# Altium schematic sheet MKRWAN_1300.SchDoc(MKRWAN_1300)
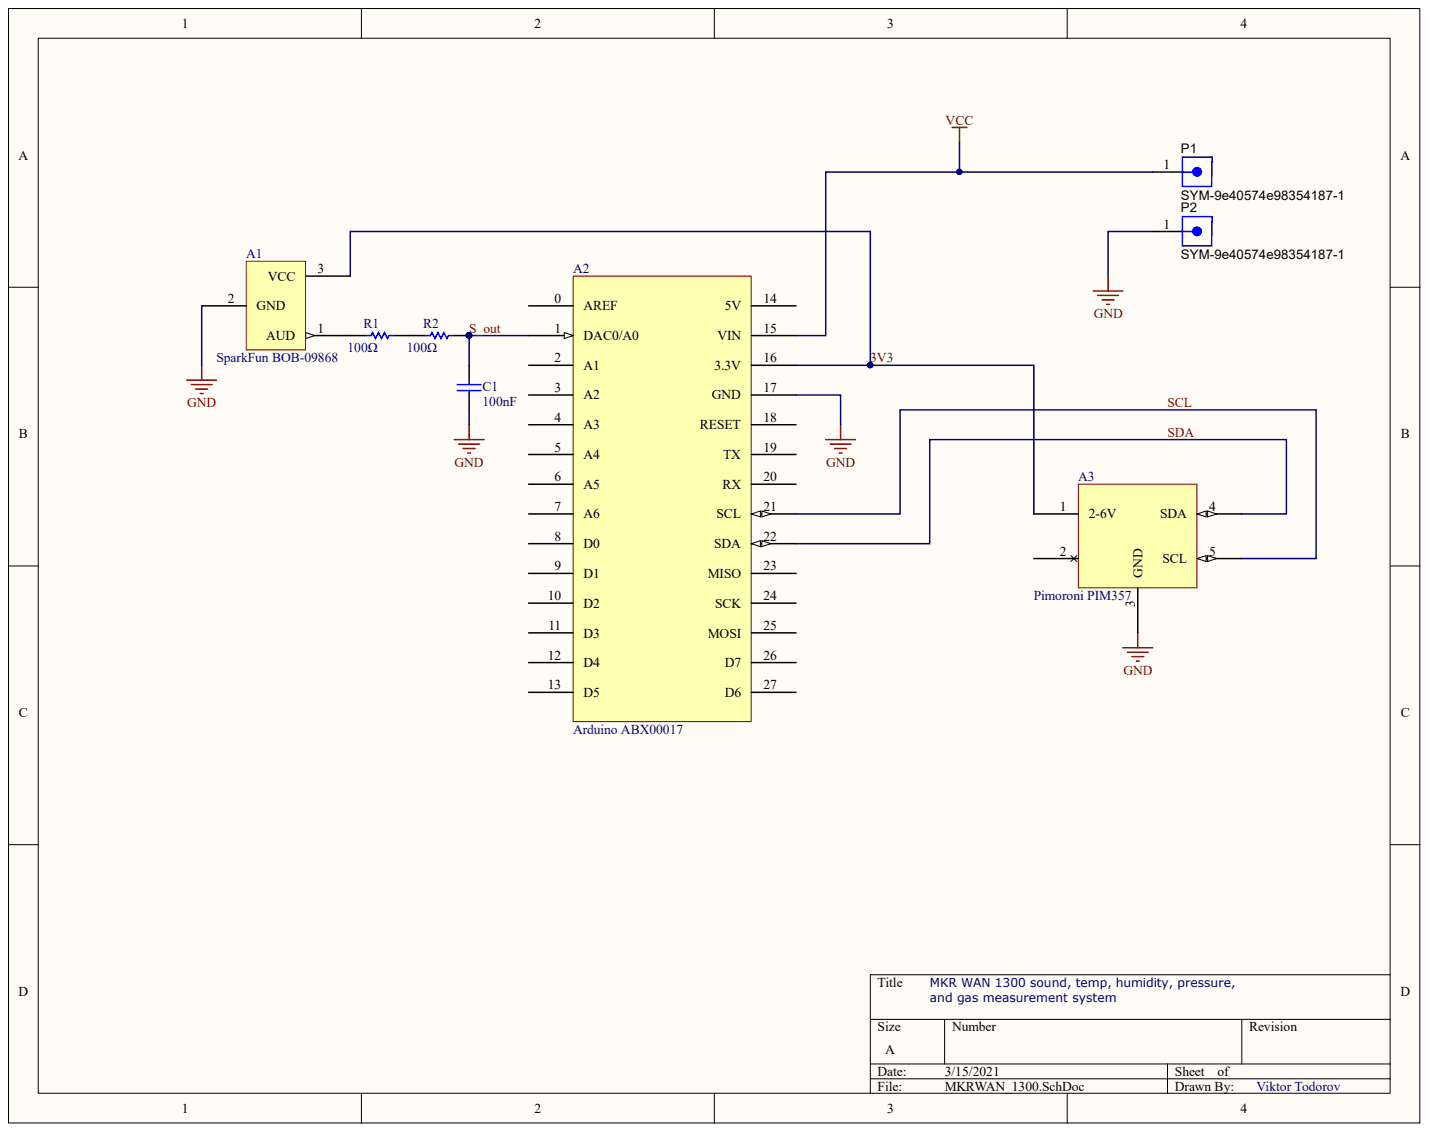
[diagram p0: MKRWAN_1300.SchDoc]

## Parts
A1  SparkFun BOB-09868  Manufacturer Part Number: BOB-09868  [PCB-1potsi048ebqysjrldfj-1]
A2  Arduino ABX00017  Manufacturer Part Number: ABX00017  [PCB-bb69x4j479kxrmlxcux2-1]
A3  Pimoroni PIM357  Manufacturer Part Number: PIM357  [PCB-qvs4aq9kb5po7iowkm1p-1]
C1  100nF  [PCB-sa3w44oy0wih14a9m5c9-1]
P1  SYM-9e40574e98354187-1  [PCB-lm1opiol0yx140ggi4xe-1]
P2  SYM-9e40574e98354187-1  [PCB-lm1opiol0yx140ggi4xe-1]
R1  value 100Ω  [PCB-uegyx4izrxx2o2dg06ir-1]  (hidden comment: TE Connectivity / AMP 1622297-1)
R2  value 100Ω  [PCB-uegyx4izrxx2o2dg06ir-1]  (hidden comment: TE Connectivity / AMP 1622297-1)

## Nets
GND: A1.2 A2.17(GND) A3.3(GND) C1.1 P2.1
VCC: A2.15(VIN) P1.1
3V3: A1.3 A2.16(3.3V) A3.1(2-6V)
S_OUT: A2.1(DAC0/A0) C1.2 R2.2
SCL: A2.21(SCL) A3.5(SCL)
SDA: A2.22(SDA) A3.4(SDA)
NetA1_1: A1.1 R1.1
NetR1_2: R1.2 R2.1

Not connected: A2 0(AREF) 2(A1) 3 4(A3) 5(A4) 6(A5) 7(A6) 8(D0) 9(D1) 10(D2) 11(D3) 12(D4) 13(D5) 14(5V) 18(RESET) 19(TX) 20(RX) 23(MISO) 24(SCK) 25(MOSI) 26(D7) 27(D6); A3 2

## Library data of the parts
A1 = CMP-6b5aa3011b4bb49d-10: ADMP401 Mems Microphone; Component Kind: Standard; Library Name: FavoritesLibrary; OctopartID: 6b5aa3011b4bb49d; Octopart URL: https://octopart.com/bob-09868-sparkfun-22107511; Weight: 0.00 g
A2 = CMP-b04a7c109d4c6f8d-12: SAMD21 Microcontroller Development Board 0.03276MHz/48MHz CPU 32KB RAM 256KB Flash; Core Architecture: ARM; Number of Bits: 32; Component Kind: Standard; Library Name: FavoritesLibrary; OctopartID: b04a7c109d4c6f8d; Octopart URL: https://octopart.com/abx00017-arduino-89131104; RoHS: Compliant
A3 = CMP-dcca10411d86030d-10: Temperature Sensor, Bme680 Breakout Rohs Compliant: Yes; Component Kind: Standard; Library Name: FavoritesLibrary; OctopartID: dcca10411d86030d; Octopart URL: https://octopart.com/pim357-pimoroni-92398634
C1 = CMP-0012c9195eeddfd5-6: Cap Ceramic 0.1uF 50V X7R 10% Radial 5.08mm 125°C Bulk; Lead Length: 7.00 mm; Capacitance: 100 nF; Component Kind: Standard; Library Name: CommunityVault; Mounting Style: Through Hole; Packaging: Bulk; Size-Length: 5.08 mm
P1 P2 = CMP-df4d166140c6008a-2: 2.00mm (0.079") Pitch SIL Vertical 2.4mm PC Tail Pin Header, 3.5mm mating pin height, gold, 2 contacts; Component Kind: Standard; Library Name: CommunityVault; OctopartID: df4d166140c6008a; Octopart URL: http://octopart.com/m22-2510205-harwin-2538221
R1 R2 = CMP-165add3b387fc99d-2: Through Hole Thin Film Resistor, LR Series, 100 ohm, 600 mW, - 1%, 350 V, Axial Leaded; Component Kind: Standard; Library Name: CommunityVault; Lifecycle Status: Active; Mounting Style: Axial; Packaging: Ammo Pack; REACH SVHC Compliance: No SVHC; Resistance Tolerance: ±1%
